# Altium schematic sheet d202.SchDoc
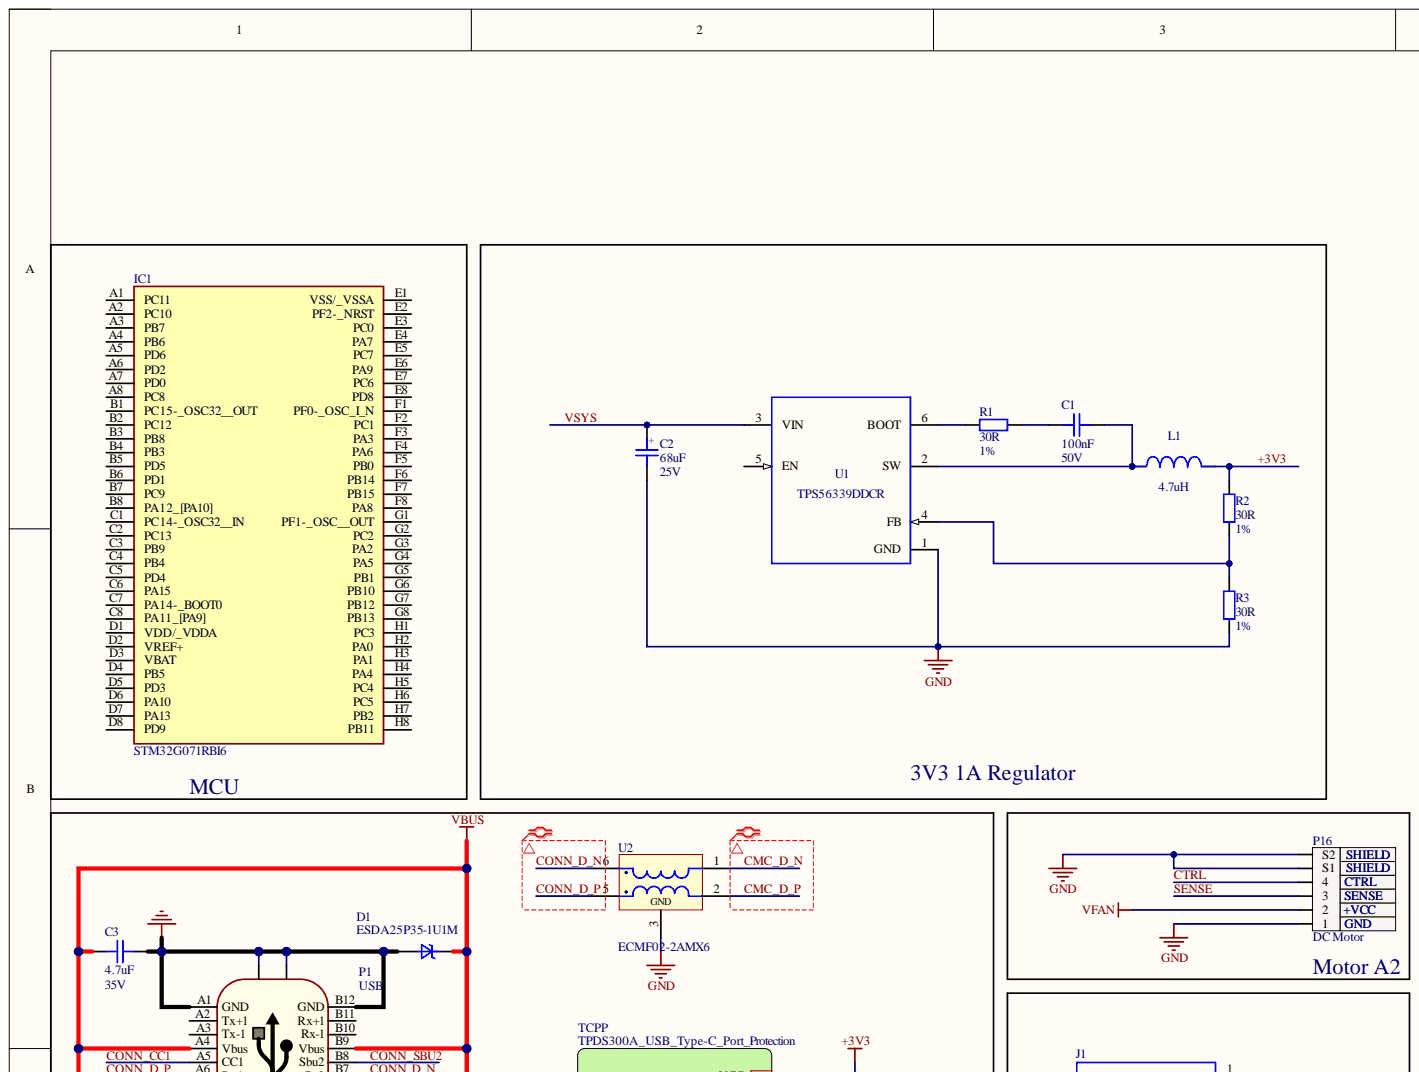
[diagram p0: d202.SchDoc, top left]
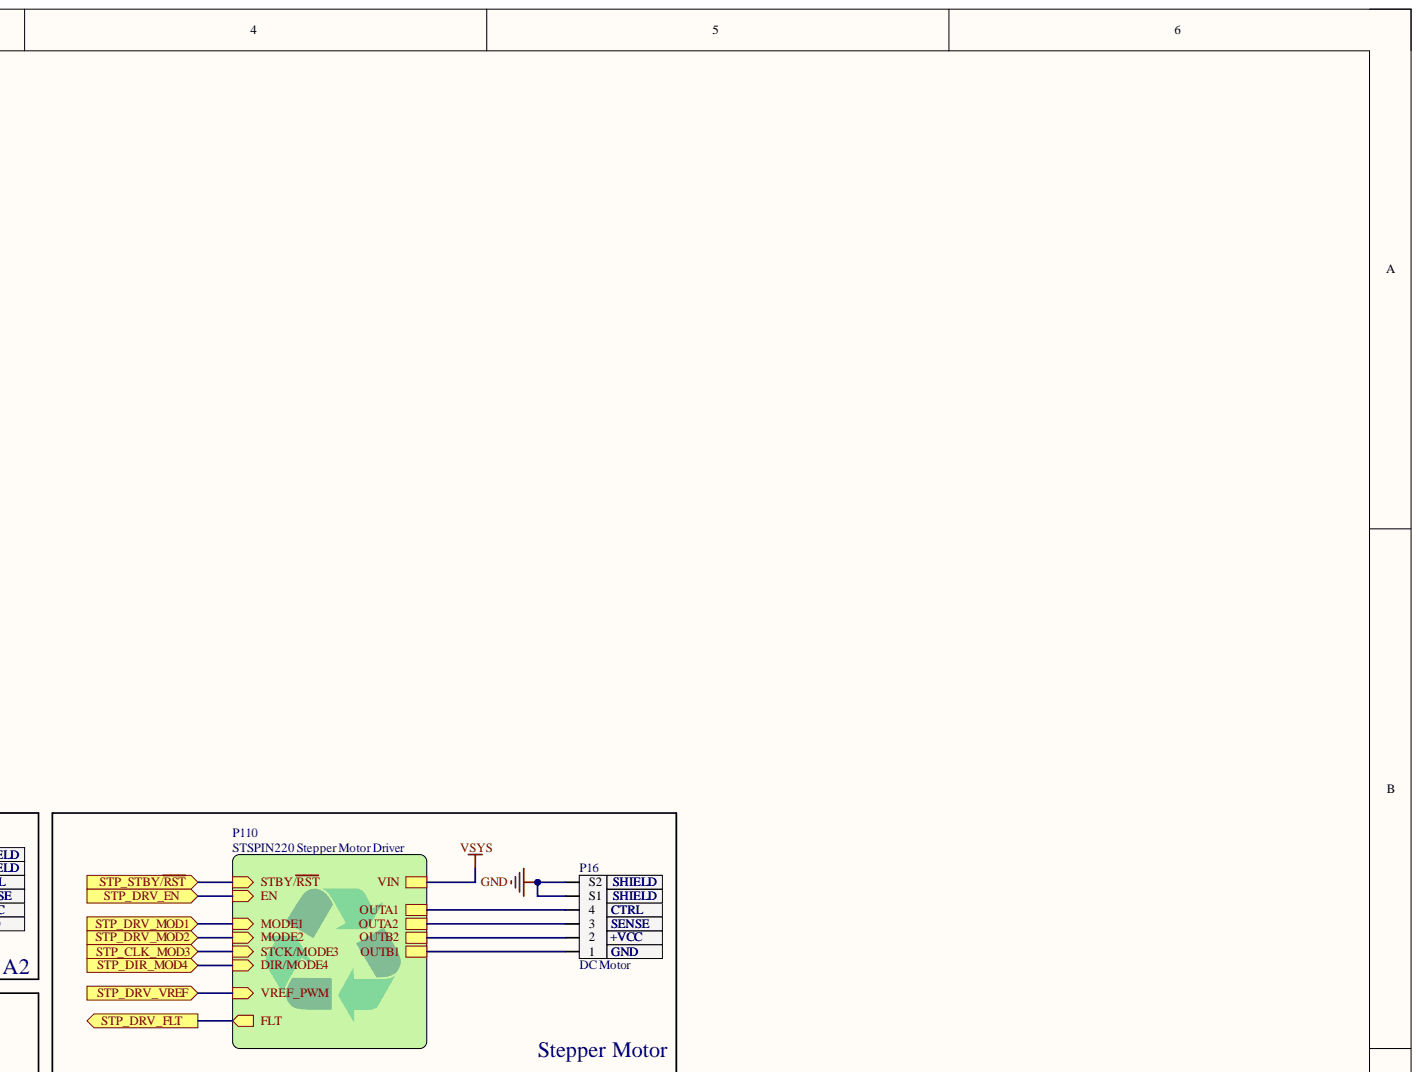
[diagram p1: d202.SchDoc, top right]
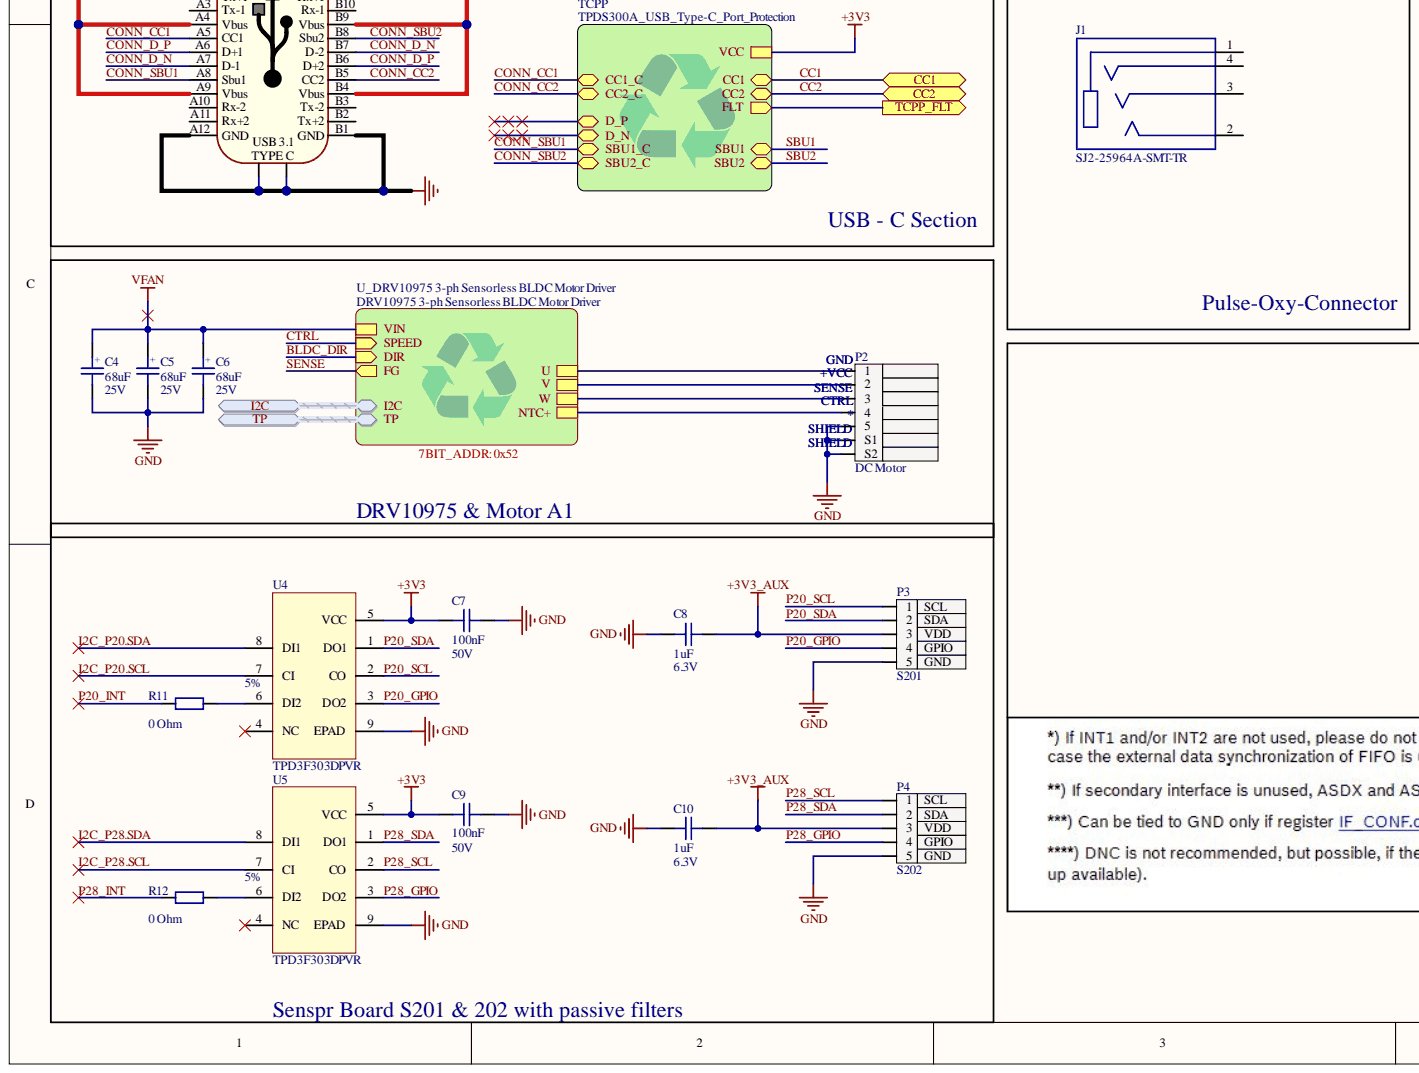
[diagram p2: d202.SchDoc, bottom left]
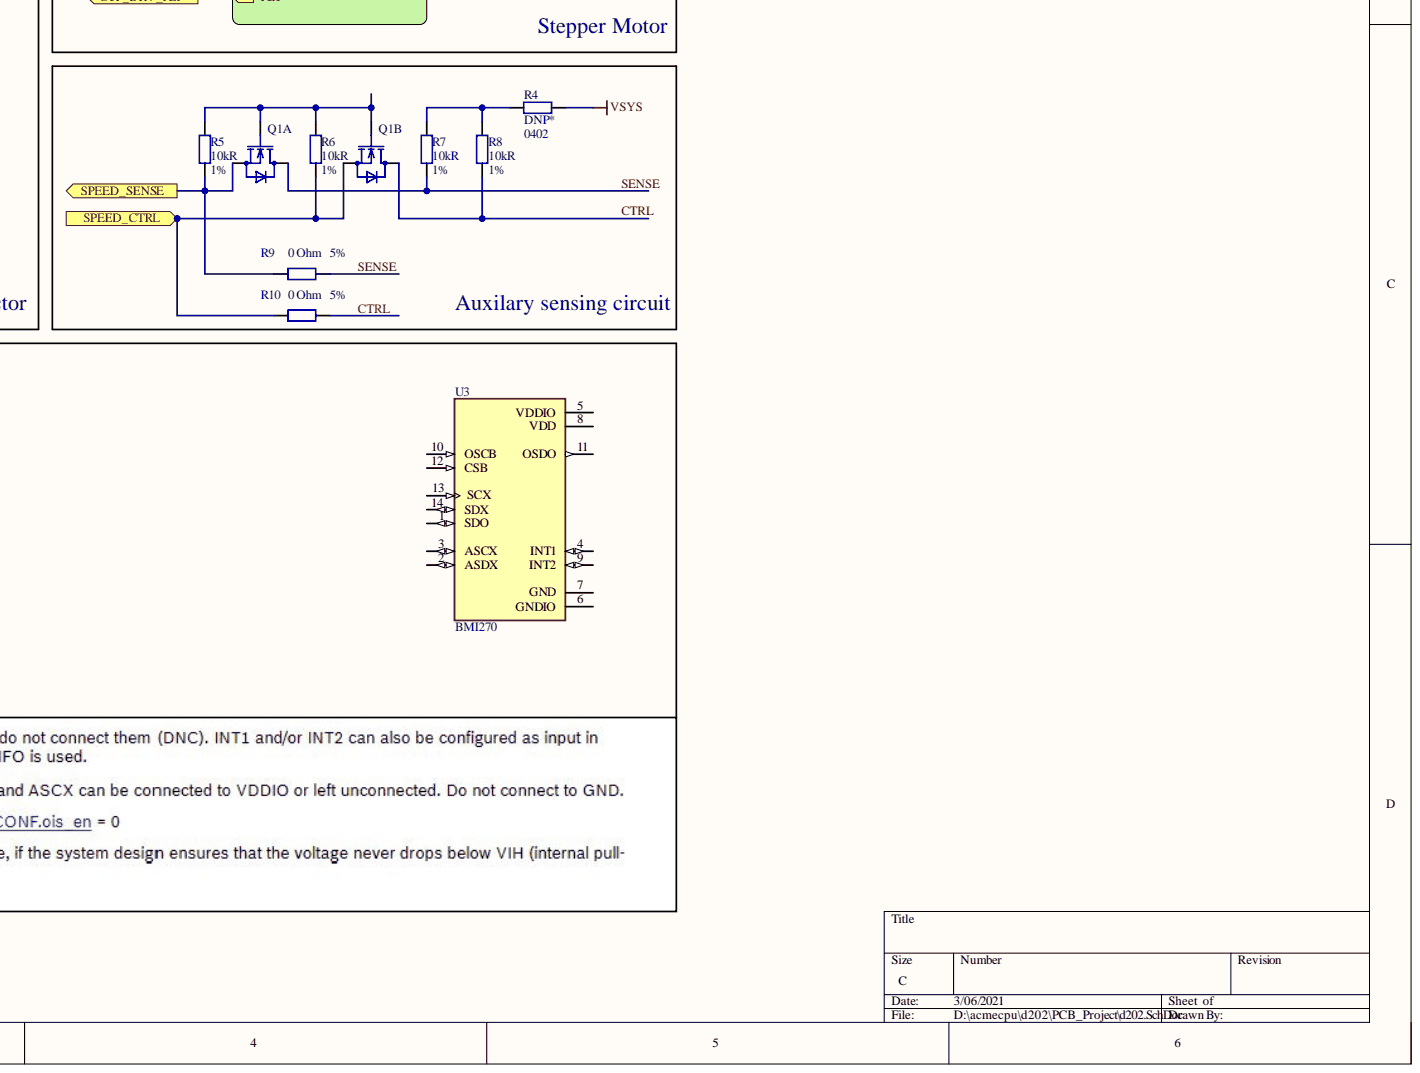
[diagram p3: d202.SchDoc, bottom right]

## Parts
C1  Capacitance: 100nF  Voltage Rating: 50V  [C0402]  (hidden comment: CAP CER 1UF 6.3V X5R 0402)
C2  Capacitance: 68uF  Rated Voltage: 25V  [WCAP-ASLI_6.3x5.5]  (hidden comment: CAP ALUM 68 UF 20% 25 V SMD)
C3  Capacitance: 4.7uF  Voltage Rating: 35V  [C0805]  (hidden comment: CAP CER 4.7UF 35V X7R 0805)
C4  Capacitance: 68uF  Rated Voltage: 25V  [WCAP-ASLI_6.3x5.5]  (hidden comment: CAP ALUM 68 UF 20% 25 V SMD)
C5  Capacitance: 68uF  Rated Voltage: 25V  [WCAP-ASLI_6.3x5.5]  (hidden comment: CAP ALUM 68 UF 20% 25 V SMD)
C6  Capacitance: 68uF  Rated Voltage: 25V  [WCAP-ASLI_6.3x5.5]  (hidden comment: CAP ALUM 68 UF 20% 25 V SMD)
C7  Capacitance: 100nF  Voltage: 50V  [C0402]  (hidden comment: CAP CER 0.1UF 50V X7R 0402)
C8  Capacitance: 1uF  Voltage Rating: 6.3V  [C0402]  (hidden comment: CAP CER 1UF 6.3V X5R 0402)
C9  Capacitance: 100nF  Voltage: 50V  [C0402]  (hidden comment: CAP CER 0.1UF 50V X7R 0402)
C10  Capacitance: 1uF  Voltage Rating: 6.3V  [C0402]  (hidden comment: CAP CER 1UF 6.3V X5R 0402)
D1  ESDA25P35-1U1M  [ST_QFN_1610]
IC1  STM32G071RBI6  [BGA64C50P8X8_500X500X60]
J1  SJ2-25964A-SMT-TR  [CUI_SJ2-25964A-SMT-TR]
L1  value 4.7uH  (hidden comment: NPI43C4R7MTRF)
P1  USB  [MOLEX_2012670005]
P2  DC Motor  [JST_S5B-ZR-SM4A-TF(LF)(SN)]
P3  S201  pin_1: SCL  pin_2: SDA  pin_3: VDD  pin_4: GPIO  pin_5: GND  [CONN_0545500571]
P4  S202  pin_1: SCL  pin_2: SDA  pin_3: VDD  pin_4: GPIO  pin_5: GND  [CONN_0545500571]
P16  DC Motor  [SM04B-NSHSS-TB (LF)(SN)]
P16  DC Motor  [JST_S4B-ZR-SM4A-TF(LF)(SN)]
Q1  DMN32D2LV-7  [SON_400UV-7_DIO]  (value not drawn)  (parts A,B)
R1  Resistance: 30R  Tolerance: 1%  [R0402]  (hidden comment: RES SMD 10K OHM 1% 1/16W 0402)
R2  Resistance: 30R  Tolerance: 1%  [R0402]  (hidden comment: RES SMD 10K OHM 1% 1/16W 0402)
R3  Resistance: 30R  Tolerance: 1%  [R0402]  (hidden comment: RES SMD 10K OHM 1% 1/16W 0402)
R4  DNP*  Case/Package: 0402  [R0402]  (no BOM)
R5  Resistance: 10kR  Tolerance: 1%  [R0402]  (hidden comment: RES SMD 10K OHM 1% 1/16W 0402)
R6  Resistance: 10kR  Tolerance: 1%  [R0402]  (hidden comment: RES SMD 10K OHM 1% 1/16W 0402)
R7  Resistance: 10kR  Tolerance: 1%  [R0402]  (hidden comment: RES SMD 10K OHM 1% 1/16W 0402)
R8  Resistance: 10kR  Tolerance: 1%  [R0402]  (hidden comment: RES SMD 10K OHM 1% 1/16W 0402)
R9  Resistance: 0 Ohm  Tolerance: 5%  [R0402]  (hidden comment: RES SMD 0 OHM JUMPER 1/16W 0402)
R10  Resistance: 0 Ohm  Tolerance: 5%  [R0402]  (hidden comment: RES SMD 0 OHM JUMPER 1/16W 0402)
R11  Resistance: 0 Ohm  [R0402]  (hidden comment: RES SMD 0 OHM JUMPER 1/16W 0402)
R12  Resistance: 0 Ohm  [R0402]  (hidden comment: RES SMD 0 OHM JUMPER 1/16W 0402)
U1  TPS56339DDCR
U2  ECMF02-2AMX6  [QFN-6L]
U3  BMI270  [XDCR_BMI270]
U4  TPD3F303DPVR  [DPV8_1P7X0P4]
U5  TPD3F303DPVR  [DPV8_1P7X0P4]

## Ports
CC1, CC2, I2C, SPEED_CTRL, SPEED_SENSE, STP_CLK_MOD3, STP_DIR_MOD4, STP_DRV_EN, STP_DRV_FLT, STP_DRV_MOD1, STP_DRV_MOD2, STP_DRV_VREF, STP_STBY/~{RST}, TP, TP.NTC, TP.SCL, TP.SDA, TP.V1P8, TP.V3P3, TP.VREG, ~{TCPP_FLT}

## Nets
+3V3_AUX: C8.2 C10.2 P3.3 P4.3
GND: C2.2 C3.2 C4.2 C5.2 C6.2 C7.2 C8.1 C9.2 C10.1 D1.2 P1.A1(GND) P1.A12(GND) P1.B1(GND) P1.B12(GND) P1.S1 P1.S2 P1.S3 P1.S4 P2.5 P2.6(S1) P2.7(S2) P3.0 P3.5 P4.0 P4.5 P16.1 P16.5(S1) P16.6(S2) P16.S1 P16.S2 R3.1 U1.1(GND) U2.3 U4.9(EPAD) U5.9(EPAD)
VBUS: C3.1 D1.1 P1.A4(Vbus) P1.A9(Vbus) P1.B4(Vbus) P1.B9(Vbus)
VFAN: C4.1 C5.1 C6.1 P16.2
+3V3: C7.1 C9.1 L1.1 R2.2 U4.5(VCC) U5.5(VCC)
~{P20_INT}: R11.1
~{P28_INT}: R12.1
CMC_D_N: U2.1
CMC_D_P: U2.2
CONN_CC1: P1.A5(CC1)
CONN_CC2: P1.B5(CC2)
CONN_D_N: P1.A7(D-1) P1.B7(D-2) U2.6
CONN_D_P: P1.A6(D+1) P1.B6(D+2) U2.5
CONN_SBU1: P1.A8(Sbu1)
CONN_SBU2: P1.B8(Sbu2)
CTRL: P16.4 Q1.3 R8.2 R10.2
I2C_P20.SCL: U4.7(CI)
I2C_P20.SDA: U4.8(DI1)
I2C_P28.SCL: U5.7(CI)
I2C_P28.SDA: U5.8(DI1)
P20_GPIO: P3.4 U4.3(DO2)
P20_SCL: P3.1 U4.2(CO)
P20_SDA: P3.2 U4.1(DO1)
P28_GPIO: P4.4 U5.3(DO2)
P28_SCL: P4.1 U5.2(CO)
P28_SDA: P4.2 U5.1(DO1)
SENSE: P16.3 Q1.6 R7.2 R9.2
SPEED_CTRL: Q1.4 R6.2 R10.1 ; port SPEED_CTRL
SPEED_SENSE: Q1.1 R5.2 R9.1 ; port SPEED_SENSE
VSYS: C2.1 R4.1 U1.3(VIN)
NetC1_1: C1.1 R1.2
NetC1_2: C1.2 L1.2 U1.2(SW)
NetIC1_A1: IC1.A1(PC11)
NetIC1_A2: IC1.A2(PC10)
NetIC1_A3: IC1.A3(PB7)
NetIC1_A4: IC1.A4(PB6)
NetIC1_A5: IC1.A5(PD6)
NetIC1_A6: IC1.A6(PD2)
NetIC1_A7: IC1.A7(PD0)
NetIC1_A8: IC1.A8(PC8)
NetIC1_B1: IC1.B1(PC15-_OSC32__OUT)
NetIC1_B2: IC1.B2(PC12)
NetIC1_B3: IC1.B3(PB8)
NetIC1_B4: IC1.B4(PB3)
NetIC1_B5: IC1.B5(PD5)
NetIC1_B6: IC1.B6(PD1)
NetIC1_B7: IC1.B7(PC9)
NetIC1_B8: IC1.B8(PA12_[PA10])
NetIC1_C1: IC1.C1(PC14-_OSC32__IN)
NetIC1_C2: IC1.C2(PC13)
NetIC1_C3: IC1.C3(PB9)
NetIC1_C4: IC1.C4(PB4)
NetIC1_C5: IC1.C5(PD4)
NetIC1_C6: IC1.C6(PA15)
NetIC1_C7: IC1.C7(PA14-_BOOT0)
NetIC1_C8: IC1.C8(PA11_[PA9])
NetIC1_D1: IC1.D1(VDD/_VDDA)
NetIC1_D2: IC1.D2(VREF+)
NetIC1_D3: IC1.D3(VBAT)
NetIC1_D4: IC1.D4(PB5)
NetIC1_D5: IC1.D5(PD3)
NetIC1_D6: IC1.D6(PA10)
NetIC1_D7: IC1.D7(PA13)
NetIC1_D8: IC1.D8(PD9)
NetIC1_E1: IC1.E1(VSS/_VSSA)
NetIC1_E2: IC1.E2(PF2-_NRST)
NetIC1_E3: IC1.E3(PC0)
NetIC1_E4: IC1.E4(PA7)
NetIC1_E5: IC1.E5(PC7)
NetIC1_E6: IC1.E6(PA9)
NetIC1_E7: IC1.E7(PC6)
NetIC1_E8: IC1.E8(PD8)
NetIC1_F1: IC1.F1(PF0-_OSC_I_N)
NetIC1_F2: IC1.F2(PC1)
NetIC1_F3: IC1.F3(PA3)
NetIC1_F4: IC1.F4(PA6)
NetIC1_F5: IC1.F5(PB0)
NetIC1_F6: IC1.F6(PB14)
NetIC1_F7: IC1.F7(PB15)
NetIC1_F8: IC1.F8(PA8)
NetIC1_G1: IC1.G1(PF1-_OSC__OUT)
NetIC1_G2: IC1.G2(PC2)
NetIC1_G3: IC1.G3(PA2)
NetIC1_G4: IC1.G4(PA5)
NetIC1_G5: IC1.G5(PB1)
NetIC1_G6: IC1.G6(PB10)
NetIC1_G7: IC1.G7(PB12)
NetIC1_G8: IC1.G8(PB13)
NetIC1_H1: IC1.H1(PC3)
NetIC1_H2: IC1.H2(PA0)
NetIC1_H3: IC1.H3(PA1)
NetIC1_H4: IC1.H4(PA4)
NetIC1_H5: IC1.H5(PC4)
NetIC1_H6: IC1.H6(PC5)
NetIC1_H7: IC1.H7(PB2)
NetIC1_H8: IC1.H8(PB11)
NetJ1_1: J1.1
NetJ1_2: J1.2
NetJ1_3: J1.3
NetJ1_4: J1.4
NetP1_A2: P1.A2(Tx+1)
NetP1_A3: P1.A3(Tx-1)
NetP1_A10: P1.A10(Rx-2)
NetP1_A11: P1.A11(Rx+2)
NetP1_B2: P1.B2(Tx+2)
NetP1_B3: P1.B3(Tx-2)
NetP1_B10: P1.B10(Rx-1)
NetP1_B11: P1.B11(Rx+1)
NetP16_1: P16.1
NetP16_2: P16.2
NetP16_3: P16.3
NetP16_4: P16.4
NetP?_1: P2.1
NetP?_2: P2.2
NetP?_3: P2.3
NetP?_4: P2.4
NetQ1_2: Q1.2 Q1.5 R5.1 R6.1
NetR1_1: R1.1 U1.6(BOOT)
NetR2_1: R2.1 R3.2 U1.4(FB)
NetR4_2: R4.2 R7.1 R8.1
NetR11_2: R11.2 U4.6(DI2)
NetR12_2: R12.2 U5.6(DI2)
NetU1_5: U1.5(EN)
NetU3_1: U3.1(SDO)
NetU3_2: U3.2(ASDX)
NetU3_3: U3.3(ASCX)
NetU3_4: U3.4(INT1)
NetU3_5: U3.5(VDDIO)
NetU3_6: U3.6(GNDIO)
NetU3_7: U3.7(GND)
NetU3_8: U3.8(VDD)
NetU3_9: U3.9(INT2)
NetU3_10: U3.10(OSCB)
NetU3_11: U3.11(OSDO)
NetU3_12: U3.12(CSB)
NetU3_13: U3.13(SCX)
NetU3_14: U3.14(SDX)
NetU4_4: U4.4(NC)
NetU5_4: U5.4(NC)
~{FLT}: ; port STP_DRV_FLT, port ~{TCPP_FLT}
BLDC_DIR:
CC1: ; port CC1
CC2: ; port CC2
D_N:
D_P:
DIR/MODE4: ; port STP_DIR_MOD4
EN: ; port STP_DRV_EN
MODE1: ; port STP_DRV_MOD1
MODE2: ; port STP_DRV_MOD2
SBU1:
SBU2:
STBY/~{RST}: ; port STP_STBY/~{RST}
STCK/MODE3: ; port STP_CLK_MOD3
TP.NTC: ; port TP
TP.SCL: ; port TP
TP.SDA: ; port TP
TP.V1P8: ; port TP
TP.V3P3: ; port TP
TP.VREG: ; port TP
VREF_PWM: ; port STP_DRV_VREF

## Library data of the parts
C1 C8 C10 = CAP CER 1UF 6.3V X5R 0402: 1µF ±10% 6.3V Ceramic Capacitor X5R 0402 (1005 Metric); Manufacturer: Yageo; Manufacturer Part Number: CC0402KRX5R5BB105; Case Code (Imperial): 0402; Case Code (Metric): 1005; Case/Package: 0402; Component Kind: Standard; ComponentLink1Description: http://www.farnell.com/datasheets/70765.pdf?src-supplier=Farnell; ComponentLink1URL: http://www.farnell.com/datasheets/70765.pdf?src-supplier=Farnell; ComponentLink2Description: http://www.yageo.com/documents/recent/UPY-GPHC_X5R_4V-to-50V_25.pdf?src-supplier=Digi-Key; ComponentLink2URL: http://www.yageo.com/documents/recent/UPY-GPHC_X5R_4V-to-50V_25.pdf?src-supplier=Digi-Key; ComponentLink3Description: http://www.farnell.com/datasheets/2167237.pdf?src-supplier=Element14; ComponentLink3URL: http://www.farnell.com/datasheets/2167237.pdf?src-supplier=Element14; ComponentLink4Description: http://www.yageo.com/documents/recent/UPY-GPHC_X5R_4V-to-50V_12.pdf?src-supplier=Avnet+Europe; ComponentLink4URL: http://www.yageo.com/documents/recent/UPY-GPHC_X5R_4V-to-50V_12.pdf?src-supplier=Avnet+Europe; ComponentLink5Description: http://www.farnell.com/datasheets/1359354.pdf?src-supplier=Element14; ComponentLink5URL: http://www.farnell.com/datasheets/1359354.pdf?src-supplier=Element14; ComponentLink6Description: http://www.farnell.com/datasheets/70765.pdf?src-supplier=Element14; ComponentLink6URL: http://www.farnell.com/datasheets/70765.pdf?src-supplier=Element14; ComponentLink7Description: http://www.yageo.com/documents/recent/UPY-GPHC_X5R_4V-to-50V_12.pdf?src-supplier=Avnet; ComponentLink7URL: http://www.yageo.com/documents/recent/UPY-GPHC_X5R_4V-to-50V_12.pdf?src-supplier=Avnet; Composition: Ceramic; Depth: 0.5mm; Dielectric: X5R; Dielectric Material: Ceramic; Halogen Free: Halogen Free; Height: 0.5mm; Lead Free: Lead Free; Length: 1mm; Library Name: capacitors_smd.SchLib; Max Operating Temperature: 85°C; Min Operating Temperature: -55°C; Mount: Surface Mount; Number of Pins: 2; Package Quantity: 1; Packaging: Tape and Reel; Pricing 1: 1=0.1, 10=0.05, 50=0.0272, 100=0.0222, 500=0.0158, 1000=0.0124, 2500=0.0113, 5000=0.0104 (USD); Radiation Hardening: No; REACH SVHC: No SVHC; Stock 1: 34926286; Supplier 1: Digi-Key; Supplier Part Number 1: 311-1438-1-ND; Termination: SMD/SMT; Thickness: 0.022inch; Tolerance: 10%; Voltage Rating (DC): 6.3V; Width: 0.02inch
C2 C4-C6 = CAP ALUM 68 UF 20% 25 V SMD: 68µF 25V Aluminum Electrolytic Capacitors Radial, Can - SMD  2000 Hrs @ 105°C; Manufacturer: Wurth Elektronik; Manufacturer Part Number: 865080443009; Capacitance Tolerance: +/-20%; Dissipation Factor: 14%; Endurance: 2000h; Leakage Current: 17uA; Ripple Current: 240mA; Technical Reference: ASED055680M025DVCTBB000; Component Kind: Standard; ComponentLink1Description: Manufacturer; ComponentLink1URL: http://www.we-online.de; ComponentLink2Description: Datasheet; ComponentLink2URL: https://www.we-online.com/catalog/datasheet/865080443009.pdf; Diameter: 6.3mm; Height: 5.5mm; Mount: Surface Mount; Mounting Technology: SMT; Operating Temperature: -55 to +105degC; Packaging: Tape and Reel; Published Date: May 2020; Supplier 1: Digi-Key; Supplier Part Number 1: 732-8437-1-ND; Library Name: capacitors_alum_electr_poly.SchLib
C3 = CAP CER 4.7UF 35V X7R 0805: Manufacturer: TDK; Manufacturer Part Number: C2012X7R1V475K125AC; Dielectric Material: Ceramic; Tolerance: 10%; Case Code (Imperial): 0805; Case Code (Metric): 2012; Case/Package: 0805; Component Kind: Standard; ComponentLink1Description: http://www.farnell.com/datasheets/2792994.pdf?src-supplier=Element14; ComponentLink1URL: http://www.farnell.com/datasheets/2792994.pdf?src-supplier=Element14; ComponentLink2Description: https://product.tdk.com/info/en/catalog/datasheets/mlcc_commercial_general_en.pdf?src-supplier=Digi-Key; ComponentLink2URL: https://product.tdk.com/info/en/catalog/datasheets/mlcc_commercial_general_en.pdf?src-supplier=Digi-Key; ComponentLink3Description: https://product.tdk.com/info/en/catalog/spec/mlccspec_commercial_general_midvoltage_en.pdf?src-supplier=Digi-Key; ComponentLink3URL: https://product.tdk.com/info/en/catalog/spec/mlccspec_commercial_general_midvoltage_en.pdf?src-supplier=Digi-Key; Depth: 1.25mm; Description: CAP CER 4.7UF 35V X7R 0805; Dielectric: X7R; Height: 1.25mm; Lead Free: Lead Free; Length: 2mm; Library Name: capacitors_smd.SchLib; Max Operating Temperature: 125°C; Min Operating Temperature: -55°C; Mount: Surface Mount; Package Quantity: 2000; Packaging: Tape and Reel; Pricing 1: 1=0.73, 10=0.519, 50=0.3752, 100=0.3416, 500=0.253, 1000=0.2151 (USD); Radiation Hardening: No; REACH SVHC: No SVHC; Stock 1: 109602; Supplier 1: Digi-Key; Supplier Part Number 1: 445-7555-1-ND; Termination: SMD/SMT; Thickness: 0.057inch; Voltage Rating (DC): 35V; Weight: 0.000194oz; Width: 0.049inch
C7 C9 = CAP CER 0.1UF 50V X7R 0402: 0.1µF ±10% 50V Ceramic Capacitor X7R 0402 (1005 Metric); Manufacturer: TDK; Manufacturer Part Number: CGA2B3X7R1H104K050BB; Case Code (Imperial): 0402; Case Code (Metric): 1005; Case/Package: 0402; Component Kind: Standard; ComponentLink1Description: http://product.tdk.com/capacitor/mlcc/en/documents/mlccspec_automotive_general_midvoltage_en.pdf?src-supplier=Future+Electronics; ComponentLink1URL: http://product.tdk.com/capacitor/mlcc/en/documents/mlccspec_automotive_general_midvoltage_en.pdf?src-supplier=Future+Electronics; ComponentLink2Description: http://www.farnell.com/datasheets/1807242.pdf?src-supplier=Element14; ComponentLink2URL: http://www.farnell.com/datasheets/1807242.pdf?src-supplier=Element14; ComponentLink3Description: http://www.mouser.com/ds/2/400/lcc_automotive_general_en-843974.pdf?src-supplier=Mouser; ComponentLink3URL: http://www.mouser.com/ds/2/400/lcc_automotive_general_en-843974.pdf?src-supplier=Mouser; ComponentLink4Description: http://www.farnell.com/datasheets/1936565.pdf?src-supplier=Element14; ComponentLink4URL: http://www.farnell.com/datasheets/1936565.pdf?src-supplier=Element14; ComponentLink5Description: http://www.farnell.com/datasheets/1735392.pdf?src-supplier=Element14; ComponentLink5URL: http://www.farnell.com/datasheets/1735392.pdf?src-supplier=Element14; Composition: Ceramic; Depth: 0.5mm; Dielectric: X7R; Height: 0.5mm; Lead Free: Lead Free; Length: 1mm; Library Name: capacitors_smd.SchLib; Max Operating Temperature: 125°C; Min Operating Temperature: -55°C; Mount: Surface Mount; Package Quantity: 1; Packaging: Tape and Reel; Pricing 1: 1=0.17, 10=0.118, 50=0.0668, 100=0.0553, 500=0.0388, 1000=0.0321, 2500=0.0299, 5000=0.0273 (USD); Ratings: AEC-Q200; REACH SVHC: No SVHC; Stock 1: 2925303; Supplier 1: Digi-Key; Supplier Part Number 1: 445-6899-1-ND; Termination: SMD/SMT; Thickness: 0.022inch; Tolerance: 10%; Voltage Rating: 50V; Voltage Rating (DC): 50V; Weight: 2.3E-05oz; Width: 0.02inch
D1 = TVS DIODE ESDA25P35-1U1M 22V 41V 1610: 41V Clamp 35A (8/20µs) Ipp Tvs Diode Surface Mount 1610; Manufacturer: STMicroelectronics; Min Breakdown Voltage: 23.3V; Number of Unidirectional Channels: 1; Peak Pulse Power: 1.4kW; Power Line Protection: No; Reverse Standoff Voltage: 22V; Case/Package: 1610; Clamping Voltage: 41V; Component Kind: Standard; ComponentLink1Description: http://www.st.com/content/ccc/resource/technical/document/datasheet/group3/e4/43/53/b9/4e/8a/40/bb/DM00312034/files/DM00312034.pdf/jcr:content/translations/en.DM00312034.pdf?src-supplier=Digi-Key; ComponentLink1URL: http://www.st.com/content/ccc/resource/technical/document/datasheet/group3/e4/43/53/b9/4e/8a/40/bb/DM00312034/files/DM00312034.pdf/jcr:content/translations/en.DM00312034.pdf?src-supplier=Digi-Key; ComponentLink2Description: http://www.farnell.com/datasheets/2236209.pdf?src-supplier=Farnell; ComponentLink2URL: http://www.farnell.com/datasheets/2236209.pdf?src-supplier=Farnell; ComponentLink3Description: http://www.farnell.com/datasheets/2236209.pdf?src-supplier=Element14; ComponentLink3URL: http://www.farnell.com/datasheets/2236209.pdf?src-supplier=Element14; ComponentLink4Description: http://www.farnell.com/datasheets/2236209.pdf?src-supplier=Newark; ComponentLink4URL: http://www.farnell.com/datasheets/2236209.pdf?src-supplier=Newark; Composition: Zener; Library Name: diodes_tvs.SchLib; Manufacturer Part Number: ESDA25P35-1U1M; Max Operating Temperature: 150°C; Min Operating Temperature: -55°C; Mount: Surface Mount; Number of Pins: 2; Packaging: Tape and Reel; Peak Pulse Current: 35A; Pricing 1: 1=0.45, 10=0.362, 25=0.3312, 100=0.2079, 250=0.2056, 500=0.1925, 1000=0.1309, 2500=0.1255 (USD); Stock 1: 12413; Supplier 1: Digi-Key; Supplier Part Number 1: 497-16913-1-ND
IC1 = STM32G071RBI6: Integrated Circuit; Manufacturer_Name: STMicroelectronics; Component Kind: Standard; Datasheet Link: https://media.digikey.com/pdf/Data%20Sheets/ST%20Microelectronics%20PDFS/STM32G071_Rev1_DS.pdf; Height: 0.6mm; Manufacturer_Part_Number: STM32G071RBI6
J1 = SJ2-25964A-SMT-TR: 2.5 mm, Stereo, Right Angle, Surface Mount (SMT), Audio Jack Connector; MF: CUI Devices; Availability: Unavailable; Component Kind: Standard; Library Name: SJ2-25964A-SMT-TR.IntLib; MP: SJ2-25964A-SMT-TR; Package: None; Price: None; SnapEDA_Link: https://www.snapeda.com/parts/SJ2-25964A-SMT-TR/CUI%20Devices/view-part/1316417/?ref=snap
L1 = NPI43C4R7MTRF: Manufacturer Name: NIC Components; Component Kind: Standard; Description: NPI43C4R7MTRF; Library Name: TI WEBENCH; Manufacturer Part Number: NPI43C4R7MTRF; PackageReference: NPI43C4R7MTRF
P1 = CONN 2012670005 RCP USB3.1 TYPEC 24P SMD RA: USB-C (USB TYPE-C) USB 3.2 Gen 2 (USB 3.1 Gen 2, Superspeed + (USB 3.1)) Receptacle Connector 24 Position Surface Mount, Right Angle; Through Hole; Manufacturer: Molex; Manufacturer Part Number: 201267-0005; Current Rating: 5A; Mating Cycles: 20000; Number of Contacts: 24; Number of Ports: 1; Shielding: Shielded; Voltage Rating: 30V; Component Kind: Standard; ComponentLink1Description: https://www.molex.com/pdm_docs/sd/2012670005_sd.pdf?src-supplier=Digi-Key; ComponentLink1URL: https://www.molex.com/pdm_docs/sd/2012670005_sd.pdf?src-supplier=Digi-Key; ComponentLink2Description: http://www.farnell.com/datasheets/2722545.pdf?src-supplier=Element14; ComponentLink2URL: http://www.farnell.com/datasheets/2722545.pdf?src-supplier=Element14; ComponentLink3Description: http://www.farnell.com/datasheets/2722545.pdf?src-supplier=Newark; ComponentLink3URL: http://www.farnell.com/datasheets/2722545.pdf?src-supplier=Newark; ComponentLink4Description: https://www.molex.com/pdm_docs/pk/2012670005-PK1.pdf?src-supplier=Digi-Key; ComponentLink4URL: https://www.molex.com/pdm_docs/pk/2012670005-PK1.pdf?src-supplier=Digi-Key; ComponentLink5Description: http://www.farnell.com/datasheets/2722545.pdf?src-supplier=Farnell; ComponentLink5URL: http://www.farnell.com/datasheets/2722545.pdf?src-supplier=Farnell; Connector Type: USB; Contact Plating: Gold; Flammability Rating: UL94 V-0; Gender: Receptacle; Insulation Color: Black; Library Name: connectors_usb.SchLib; Max Operating Temperature: 105°C; Min Operating Temperature: -40°C; Mount: Surface Mount,Through Hole; Packaging: Tape and Reel; Pricing 1: 1=2.34, 10=1.997, 100=1.6496 (USD); Stock 1: 2580; Supplier 1: Digi-Key; Supplier Part Number 1: WM17992CT-ND; Termination: Solder
P2 = JST_S5B-ZR-SM4A-TF(LF)(SN): Connector Header Through Hole, Right Angle 4 position 0.059" (1.50mm); Manufacturer: JST; Manufacturer Part Number: S4B-ZR(LF)(SN); Body Plating: Tin; Connector Type: Header,Male Pin; Contact Resistance: 30mR; Current Rating: 1A; Gender: Male; Insulation Resistance: 500MR; Max Voltage Rating (AC): 50V; Number of Contacts: 4; Panel Thickness: 3.5mm; Pitch: 1.5mm; Color: White; Component Kind: Standard; ComponentLink1Description: https://www.verical.com/server-webapp/html/getDatasheet?partID=763273&src-supplier=Verical; ComponentLink1URL: https://www.verical.com/server-webapp/html/getDatasheet?partID=763273&src-supplier=Verical; ComponentLink2Description: https://www.verical.com/server-webapp/html/getDatasheet?partID=640380&src-supplier=Verical; ComponentLink2URL: https://www.verical.com/server-webapp/html/getDatasheet?partID=640380&src-supplier=Verical; ComponentLink3Description: http://www1.futureelectronics.com/doc/JST/S4B-ZR(LF)(SN).pdf?src-supplier=Future+Electronics; ComponentLink3URL: http://www1.futureelectronics.com/doc/JST/S4B-ZR(LF)(SN).pdf?src-supplier=Future+Electronics; ComponentLink4Description: http://www.jst-mfg.com/product/pdf/eng/eZH.pdf?src-supplier=Digi-Key; ComponentLink4URL: http://www.jst-mfg.com/product/pdf/eng/eZH.pdf?src-supplier=Digi-Key; ComponentLink5Description: http://www.farnell.com/datasheets/80556.pdf?src-supplier=Element14; ComponentLink5URL: http://www.farnell.com/datasheets/80556.pdf?src-supplier=Element14; ComponentLink6Description: https://www.verical.com/server-webapp/html/getDatasheet?partID=703398&src-supplier=Verical; ComponentLink6URL: https://www.verical.com/server-webapp/html/getDatasheet?partID=703398&src-supplier=Verical; Contact Material: Brass,Tin,Copper; Contact Mating Length: 0.094inch; Contact Plating: Tin,Copper; Contact Shape: Circular; Depth: 6mm; Fastening Type: Detent; Flammability Rating: UL94 V-0; Height: 7.2mm; Housing Color: Beige; Housing Material: Polyamide; Insulation Color: Natural; Insulation Material: Nylon; Lead Free: Lead Free; Lead Pitch: 1.5mm; Length: 7.5mm; Library Name: JST-ZR-v1.1.IntLib; Material: Nylon; Max Current Rating: 1A; Max Operating Temperature: 85°C; Max Voltage Rating (DC): 50V; Min Operating Temperature: -25°C; Mount: Through Hole; Number of Pins: 4; Number of Positions: 4; Number of Rows: 1; Orientation: Right Angle; Packaging: Bulk; REACH SVHC: No SVHC; Stock 1: 0; Supplier 1: Arrow; Supplier Part Number 1: S4B-ZR(LF)(SN); Termination: Solder; Voltage Rating: 50V; Voltage Rating (AC): 50V; Voltage Rating (DC): 50V; Width: 3.5mm
P3 P4 = CONN FFC FPC TOP 5POS 0.50MM R/A: 5 Position FFC, FPC Connector Contacts, Top 0.020" (0.50mm) Surface Mount, Right Angle; Manufacturer: Molex; Manufacturer Part Number: 0545500571; Actuator Material: Polyphenylene; Contact Resistance: 40mR; Current Rating: 500mA; Number of Positions: 5; Pitch: 0.02inch; Voltage Rating: 50V; Wire/Cable Type: Straight; Component Kind: Standard; ComponentLink1Description: http://www.molex.com/webdocs/datasheets/pdf/en-us/0545500571_FFC_FPC_CONNECTORS.pdf?src-supplier=Avnet; ComponentLink1URL: http://www.molex.com/webdocs/datasheets/pdf/en-us/0545500571_FFC_FPC_CONNECTORS.pdf?src-supplier=Avnet; Contact Material: Bronze; Contact Plating: Gold; Depth: 5mm; Flammability Rating: UL94 V-0; Housing Material: Polymer; Length: 7.7mm; Library Name: connectors_ffc.SchLib; Max Operating Temperature: 85°C; Min Operating Temperature: -20°C; Mount: Surface Mount; Number of Rows: 1; Orientation: Right Angle; Package Quantity: 1; Packaging: Tape and Reel; Pricing 1: 1=0.98, 10=0.808, 100=0.673, 1000=0.5384 (USD); Radiation Hardening: No; Stock 1: 20865; Supplier 1: Digi-Key; Supplier Part Number 1: WM8824CT-ND; Termination: Solder
P16 = SM04B-NSHSS-TB (LF)(SN): Connector Header SMD, Right Angle 4 position 0.039" (1.0mm); Manufacturer: JST; Manufacturer Part Number: S4B-ZR(LF)(SN); Body Plating: Tin; Connector Type: Header,Male Pin; Contact Resistance: 30mR; Current Rating: 1A; Gender: Male; Insulation Resistance: 500MR; Max Voltage Rating (AC): 50V; Number of Contacts: 4; Panel Thickness: 3.5mm; Pitch: 1.5mm; Color: White; Component Kind: Standard; ComponentLink1Description: https://www.verical.com/server-webapp/html/getDatasheet?partID=763273&src-supplier=Verical; ComponentLink1URL: https://www.verical.com/server-webapp/html/getDatasheet?partID=763273&src-supplier=Verical; ComponentLink2Description: https://www.verical.com/server-webapp/html/getDatasheet?partID=640380&src-supplier=Verical; ComponentLink2URL: https://www.verical.com/server-webapp/html/getDatasheet?partID=640380&src-supplier=Verical; ComponentLink3Description: http://www1.futureelectronics.com/doc/JST/S4B-ZR(LF)(SN).pdf?src-supplier=Future+Electronics; ComponentLink3URL: http://www1.futureelectronics.com/doc/JST/S4B-ZR(LF)(SN).pdf?src-supplier=Future+Electronics; ComponentLink4Description: http://www.jst-mfg.com/product/pdf/eng/eZH.pdf?src-supplier=Digi-Key; ComponentLink4URL: http://www.jst-mfg.com/product/pdf/eng/eZH.pdf?src-supplier=Digi-Key; ComponentLink5Description: http://www.farnell.com/datasheets/80556.pdf?src-supplier=Element14; ComponentLink5URL: http://www.farnell.com/datasheets/80556.pdf?src-supplier=Element14; ComponentLink6Description: https://www.verical.com/server-webapp/html/getDatasheet?partID=703398&src-supplier=Verical; ComponentLink6URL: https://www.verical.com/server-webapp/html/getDatasheet?partID=703398&src-supplier=Verical; Contact Material: Brass,Tin,Copper; Contact Mating Length: 0.094inch; Contact Plating: Tin,Copper; Contact Shape: Circular; Depth: 6mm; Fastening Type: Detent; Flammability Rating: UL94 V-0; Height: 7.2mm; Housing Color: Beige; Housing Material: Polyamide; Insulation Color: Natural; Insulation Material: Nylon; Lead Free: Lead Free; Lead Pitch: 1.5mm; Length: 7.5mm; Library Name: JST-ZR-v1.3.IntLib; Material: Nylon; Max Current Rating: 1A; Max Operating Temperature: 85°C; Max Voltage Rating (DC): 50V; Min Operating Temperature: -25°C; Mount: Through Hole; Number of Pins: 4; Number of Positions: 4; Number of Rows: 1; Orientation: Right Angle; Packaging: Bulk; REACH SVHC: No SVHC; Stock 1: 0; Supplier 1: Arrow; Supplier Part Number 1: S4B-ZR(LF)(SN); Termination: Solder; Voltage Rating: 50V; Voltage Rating (AC): 50V; Voltage Rating (DC): 50V; Width: 3.5mm
P16 = JST_S4B-ZR-SM4A-TF(LF)(SN): Connector Header Through Hole, Right Angle 4 position 0.059" (1.50mm); Manufacturer: JST; Manufacturer Part Number: S4B-ZR(LF)(SN); Body Plating: Tin; Connector Type: Header,Male Pin; Contact Resistance: 30mR; Current Rating: 1A; Gender: Male; Insulation Resistance: 500MR; Max Voltage Rating (AC): 50V; Number of Contacts: 4; Panel Thickness: 3.5mm; Pitch: 1.5mm; Color: White; Component Kind: Standard; ComponentLink1Description: https://www.verical.com/server-webapp/html/getDatasheet?partID=763273&src-supplier=Verical; ComponentLink1URL: https://www.verical.com/server-webapp/html/getDatasheet?partID=763273&src-supplier=Verical; ComponentLink2Description: https://www.verical.com/server-webapp/html/getDatasheet?partID=640380&src-supplier=Verical; ComponentLink2URL: https://www.verical.com/server-webapp/html/getDatasheet?partID=640380&src-supplier=Verical; ComponentLink3Description: http://www1.futureelectronics.com/doc/JST/S4B-ZR(LF)(SN).pdf?src-supplier=Future+Electronics; ComponentLink3URL: http://www1.futureelectronics.com/doc/JST/S4B-ZR(LF)(SN).pdf?src-supplier=Future+Electronics; ComponentLink4Description: http://www.jst-mfg.com/product/pdf/eng/eZH.pdf?src-supplier=Digi-Key; ComponentLink4URL: http://www.jst-mfg.com/product/pdf/eng/eZH.pdf?src-supplier=Digi-Key; ComponentLink5Description: http://www.farnell.com/datasheets/80556.pdf?src-supplier=Element14; ComponentLink5URL: http://www.farnell.com/datasheets/80556.pdf?src-supplier=Element14; ComponentLink6Description: https://www.verical.com/server-webapp/html/getDatasheet?partID=703398&src-supplier=Verical; ComponentLink6URL: https://www.verical.com/server-webapp/html/getDatasheet?partID=703398&src-supplier=Verical; Contact Material: Brass,Tin,Copper; Contact Mating Length: 0.094inch; Contact Plating: Tin,Copper; Contact Shape: Circular; Depth: 6mm; Fastening Type: Detent; Flammability Rating: UL94 V-0; Height: 7.2mm; Housing Color: Beige; Housing Material: Polyamide; Insulation Color: Natural; Insulation Material: Nylon; Lead Free: Lead Free; Lead Pitch: 1.5mm; Length: 7.5mm; Library Name: JST-ZR-v1.1.IntLib; Material: Nylon; Max Current Rating: 1A; Max Operating Temperature: 85°C; Max Voltage Rating (DC): 50V; Min Operating Temperature: -25°C; Mount: Through Hole; Number of Pins: 4; Number of Positions: 4; Number of Rows: 1; Orientation: Right Angle; Packaging: Bulk; REACH SVHC: No SVHC; Stock 1: 0; Supplier 1: Arrow; Supplier Part Number 1: S4B-ZR(LF)(SN); Termination: Solder; Voltage Rating: 50V; Voltage Rating (AC): 50V; Voltage Rating (DC): 50V; Width: 3.5mm
Q1 = ARRAY MOSFET 2N-CH 30V 0.4A SOT-563: Mosfet Array 2 N-Channel (Dual) 30V 400mA 400mW Surface Mount SOT-563; Manufacturer: Diodes; Drain to Source Resistance: 1.2R; Gate to Source Voltage (Vgs): 10V; Input Capacitance: 39pF; Number of Channels: 2; Case/Package: SOT-563; Component Kind: Standard; ComponentLink1Description: https://www.diodes.com/assets/Datasheets/ds31121.pdf?src-supplier=Digi-Key; ComponentLink1URL: https://www.diodes.com/assets/Datasheets/ds31121.pdf?src-supplier=Digi-Key; ComponentLink2Description: http://www.mouser.com/ds/2/115/ds31121-89496.pdf?src-supplier=Mouser; ComponentLink2URL: http://www.mouser.com/ds/2/115/ds31121-89496.pdf?src-supplier=Mouser; ComponentLink3Description: http://www.diodes.com///datasheets/ds31121.pdf?src-supplier=Avnet; ComponentLink3URL: http://www.diodes.com///datasheets/ds31121.pdf?src-supplier=Avnet; Continuous Drain Current (ID): 400mA; Drain to Source Breakdown Voltage: 30V; Drain to Source Voltage (Vdss): 30V; Element Configuration: Dual; FET Type: 2 N-Channel; Height: 0.6mm; Length: 1.6mm; Library Name: mosfets.SchLib; Manufacturer Part Number: DMN32D2LV-7; Max Operating Temperature: 150°C; Max Power Dissipation: 400mW; Min Operating Temperature: -55°C; Mount: Surface Mount; Package Quantity: 3000; Packaging: Tape and Reel; Polarity: N-CHANNEL; Pricing 1: 1=0.39, 10=0.338, 25=0.3152, 100=0.234, 500=0.1827, 1000=0.1485 (USD); Radiation Hardening: No; Rds On Max: 1.2R; REACH SVHC: No SVHC; Series: -; Stock 1: 141366; Supplier 1: Digi-Key; Supplier Part Number 1: DMN32D2LV-7DICT-ND; Weight: 0.000106oz; Width: 1.2mm
R1-R3 R5-R8 = RES SMD 10K OHM 1% 1/16W 0402: 10 kOhms ±1% 0.063W, 1/16W Chip Resistor 0402 (1005 Metric) Moisture Resistant Thick Film; Manufacturer: Yageo; Manufacturer Part Number: RC0402FR-0710KP; Max Power Dissipation: 63mW; Temperature Coefficient: 150ppm/°C; Case Code (Imperial): 0402; Case Code (Metric): 1005; Case/Package: 0402; Component Kind: Standard; ComponentLink1Description: http://www.yageo.com/NewPortal/yageodocoutput?fileName=/pdf/R-Chip/PYu-RC_51_RoHS_P_0.pdf&src-supplier=Digi-Key; ComponentLink1URL: http://www.yageo.com/NewPortal/yageodocoutput?fileName=/pdf/R-Chip/PYu-RC_51_RoHS_P_0.pdf&src-supplier=Digi-Key; Composition: Thick Film; Features: Moisture Resistant; Height: 0.016inch; Length: 0.039inch; Library Name: resistors_smd.SchLib; Max Operating Temperature: 155°C; Min Operating Temperature: -55°C; Mount: Surface Mount; Number of Terminations: 2; Packaging: Tape and Reel; Pricing 1: 1=0.1, 10=0.035, 100=0.014, 1000=0.0063, 2500=0.0055, 5000=0.0045 (USD); Stock 1: 839368; Supplier 1: Digi-Key; Supplier Part Number 1: YAG1249CT-ND; Width: 0.02inch
R4 = RES SMD NO BOM 0402: Placeholder for a 0402 resistor; Library Name: resistors_smd.SchLib
R9 R10 = RES SMD 0 OHM JUMPER 1/16W 0402: 0 Ohms Jumper 0.063W, 1/16W Chip Resistor 0402 (1005 Metric) Moisture Resistant Thick Film; Manufacturer: Yageo; Manufacturer Part Number: RC0402JR-070RL; Max Power Dissipation: 63mW; Power Rating: 62.5mW; Temperature Coefficient: 200ppm/°C; Voltage Rating: 50V; Case Code (Imperial): 0402; Case Code (Metric): 1005; Case/Package: 0402; Component Kind: Standard; ComponentLink1Description: http://www.yageo.com/documents/recent/PYu-RC_Group_51_RoHS_L_10.pdf?src-supplier=Digi-Key; ComponentLink1URL: http://www.yageo.com/documents/recent/PYu-RC_Group_51_RoHS_L_10.pdf?src-supplier=Digi-Key; ComponentLink2Description: http://www.yageo.com/documents/recent/PYu-RC0402_51_RoHS_L_6.pdf?src-supplier=Avnet+Europe; ComponentLink2URL: http://www.yageo.com/documents/recent/PYu-RC0402_51_RoHS_L_6.pdf?src-supplier=Avnet+Europe; ComponentLink3Description: http://www.farnell.com/datasheets/1018594.pdf?src-supplier=Element14; ComponentLink3URL: http://www.farnell.com/datasheets/1018594.pdf?src-supplier=Element14; ComponentLink4Description: https://www.verical.com/server-webapp/html/getDatasheet?partID=326379&src-supplier=Verical; ComponentLink4URL: https://www.verical.com/server-webapp/html/getDatasheet?partID=326379&src-supplier=Verical; ComponentLink5Description: http://www.farnell.com/datasheets/2167052.pdf?src-supplier=Element14; ComponentLink5URL: http://www.farnell.com/datasheets/2167052.pdf?src-supplier=Element14; Composition: Thick Film; Contact Plating: Tin,Matte; Depth: 0.5mm; Features: Moisture Resistant; Height: 0.016inch; Lead Free: Lead Free; Length: 1mm; Library Name: resistors_smd.SchLib; Max Operating Temperature: 155°C; Military Standard: Not; Min Operating Temperature: -55°C; Mount: Surface Mount; Number of Terminations: 2; Package Quantity: 1; Packaging: Tape and Reel; Pricing 1: 1=0.1, 10=0.013, 25=0.0092, 50=0.0072, 100=0.0053, 250=0.004, 500=0.0032, 1000=0.0024, 5000=0.0017 (USD); Radiation Hardening: No; REACH SVHC: Unknown; Stock 1: 46057148; Supplier 1: Digi-Key; Supplier Part Number 1: 311-0.0JRCT-ND; Termination: SMD/SMT; Width: 0.02inch
R11 R12 = RES SMD 0 OHM JUMPER 1/16W 0402: 0 Ohms Jumper 0.063W, 1/16W Chip Resistor 0402 (1005 Metric) Moisture Resistant Thick Film; Manufacturer: Yageo; Manufacturer Part Number: RC0402JR-070RL; Max Power Dissipation: 63mW; Power Rating: 62.5mW; Temperature Coefficient: 200ppm/°C; Tolerance: 5%; Voltage Rating: 50V; Case Code (Imperial): 0402; Case Code (Metric): 1005; Case/Package: 0402; Component Kind: Standard; ComponentLink1Description: http://www.yageo.com/documents/recent/PYu-RC_Group_51_RoHS_L_10.pdf?src-supplier=Digi-Key; ComponentLink1URL: http://www.yageo.com/documents/recent/PYu-RC_Group_51_RoHS_L_10.pdf?src-supplier=Digi-Key; ComponentLink2Description: http://www.yageo.com/documents/recent/PYu-RC0402_51_RoHS_L_6.pdf?src-supplier=Avnet+Europe; ComponentLink2URL: http://www.yageo.com/documents/recent/PYu-RC0402_51_RoHS_L_6.pdf?src-supplier=Avnet+Europe; ComponentLink3Description: http://www.farnell.com/datasheets/1018594.pdf?src-supplier=Element14; ComponentLink3URL: http://www.farnell.com/datasheets/1018594.pdf?src-supplier=Element14; ComponentLink4Description: https://www.verical.com/server-webapp/html/getDatasheet?partID=326379&src-supplier=Verical; ComponentLink4URL: https://www.verical.com/server-webapp/html/getDatasheet?partID=326379&src-supplier=Verical; ComponentLink5Description: http://www.farnell.com/datasheets/2167052.pdf?src-supplier=Element14; ComponentLink5URL: http://www.farnell.com/datasheets/2167052.pdf?src-supplier=Element14; Composition: Thick Film; Contact Plating: Tin,Matte; Depth: 0.5mm; Features: Moisture Resistant; Height: 0.016inch; Lead Free: Lead Free; Length: 1mm; Library Name: resistors_smd.SchLib; Max Operating Temperature: 155°C; Military Standard: Not; Min Operating Temperature: -55°C; Mount: Surface Mount; Number of Terminations: 2; Package Quantity: 1; Packaging: Tape and Reel; Pricing 1: 1=0.1, 10=0.013, 25=0.0092, 50=0.0072, 100=0.0053, 250=0.004, 500=0.0032, 1000=0.0024, 5000=0.0017 (USD); Radiation Hardening: No; REACH SVHC: Unknown; Stock 1: 46057148; Supplier 1: Digi-Key; Supplier Part Number 1: 311-0.0JRCT-ND; Termination: SMD/SMT; Width: 0.02inch
U1 = TPS56339DDCR: Manufacturer Name: Texas Instruments; Component Kind: Standard; Description: TPS56339DDCR; Library Name: TI WEBENCH; Manufacturer Part Number: TPS56339DDCR; PackageReference: TPS56339DDCR; Value: N/A
U2 = CMC 200MA 2LN SMD ESD: 2 Line Common Mode Choke Surface Mount  200mA DCR 2.5Ohm; Manufacturer: STMicroelectronics; DC Resistance (DCR): 2.5R; Number of Lines: 2; Case/Package: QFN; Component Kind: Standard; ComponentLink1Description: http://www.st.com/web/en/resource/technical/document/datasheet/CD00282307.pdf?src-supplier=Future+Electronics; ComponentLink1URL: http://www.st.com/web/en/resource/technical/document/datasheet/CD00282307.pdf?src-supplier=Future+Electronics; ComponentLink2Description: http://www.st.com/content/ccc/resource/technical/document/datasheet/3a/28/cf/d0/31/63/48/8c/CD00282307.pdf/files/CD00282307.pdf/jcr:content/translations/en.CD00282307.pdf?src-supplier=Digi-Key; ComponentLink2URL: http://www.st.com/content/ccc/resource/technical/document/datasheet/3a/28/cf/d0/31/63/48/8c/CD00282307.pdf/files/CD00282307.pdf/jcr:content/translations/en.CD00282307.pdf?src-supplier=Digi-Key; ComponentLink3Description: http://www.mouser.com/ds/2/389/ecmf02-2amx6-954491.pdf?src-supplier=Mouser; ComponentLink3URL: http://www.mouser.com/ds/2/389/ecmf02-2amx6-954491.pdf?src-supplier=Mouser; ComponentLink4Description: https://www.verical.com/server-webapp/html/getDatasheet?partID=259862&src-supplier=Verical; ComponentLink4URL: https://www.verical.com/server-webapp/html/getDatasheet?partID=259862&src-supplier=Verical; Current: 200mA; Current Rating: 200mA; Depth: 1.55mm; Height: 0.022inch; Lead Free: Lead Free; Length: 1.75mm; Library Name: diodes_tvs.SchLib; Manufacturer Part Number: ECMF02-2AMX6; Max Current Rating: 200mA; Max Operating Temperature: 85°C; Min Operating Temperature: -40°C; Mount: Surface Mount; Number of Pins: 6; Package Quantity: 1; Packaging: Tape and Reel; Pricing 1: 1=0.48, 10=0.418, 25=0.3888, 50=0.3288, 100=0.2989, 250=0.281, 500=0.2511, 1000=0.2033 (USD); Radiation Hardening: No; REACH SVHC: No SVHC; Stock 1: 23653; Supplier 1: Digi-Key; Supplier Part Number 1: 497-10773-1-ND; Termination: Flat; Width: 0.059inch
U3 = BMI270: Accelerometer, Gyroscope, 6 Axis Sensor IÂ²C, SPI Output; MF: Bosch Sensortec; Availability: Unavailable; Component Kind: Standard; Library Name: BMI270.IntLib; MP: BMI270; Package: LGA-14 Bosch Sensortec; Price: None; SnapEDA_Link: https://www.snapeda.com/parts/BMI270/Bosch%20Sensortec/view-part/3595167/?ref=snap
U4 U5 = FILTER RC(PI) ESD SMD  8-UFDFN EP: RC (Pi) EMI Filter 2nd Order Low Pass 3 Channel R = 47Ohms, 100Ohms, C = 20pF  8-UFDFN Exposed Pad; Manufacturer: Texas Instruments; Clamping Voltage: 10V; ESD Protection: Yes; Frequency: 308MHz; Number of Channels: 3; Operating Supply Voltage: 5.5V; Capacitance: 20pF; Case/Package: SMD/SMT; Component Kind: Standard; ComponentLink1Description: http://www.ti.com/lit/ds/symlink/tpd3f303.pdf?src-supplier=Digi-Key; ComponentLink1URL: http://www.ti.com/lit/ds/symlink/tpd3f303.pdf?src-supplier=Digi-Key; ComponentLink2Description: http://focus.ti.com/lit/ds/symlink/tpd3f303.pdf?src-supplier=Avnet+Europe; ComponentLink2URL: http://focus.ti.com/lit/ds/symlink/tpd3f303.pdf?src-supplier=Avnet+Europe; ComponentLink3Description: https://www.verical.com/server-webapp/html/getDatasheet?partID=302817&src-supplier=Verical; ComponentLink3URL: https://www.verical.com/server-webapp/html/getDatasheet?partID=302817&src-supplier=Verical; ComponentLink4Description: http://www.farnell.com/datasheets/1558442.pdf?src-supplier=Element14; ComponentLink4URL: http://www.farnell.com/datasheets/1558442.pdf?src-supplier=Element14; Contact Plating: Gold; Depth: 1.7mm; Height: 0.026inch; Lead Free: Lead Free; Length: 2.2mm; Library Name: diodes_tvs.SchLib; Manufacturer Part Number: TPD3F303DPVR; Max Operating Temperature: 85°C; Min Operating Temperature: -40°C; Mount: Surface Mount; Number of Pins: 8; Package Quantity: 1; Packaging: Tape and Reel; Pricing 1: 1=0.85, 10=0.812, 25=0.7856, 50=0.6414, 100=0.576, 250=0.5236, 500=0.4974, 1000=0.4451 (USD); Radiation Hardening: No; REACH SVHC: No SVHC; Stock 1: 4896; Supplier 1: Digi-Key; Supplier Part Number 1: 296-28299-1-ND; Termination: Flat; Width: 0.063inch
